# Altium schematic sheet A4988_motor_drivers.SchDoc
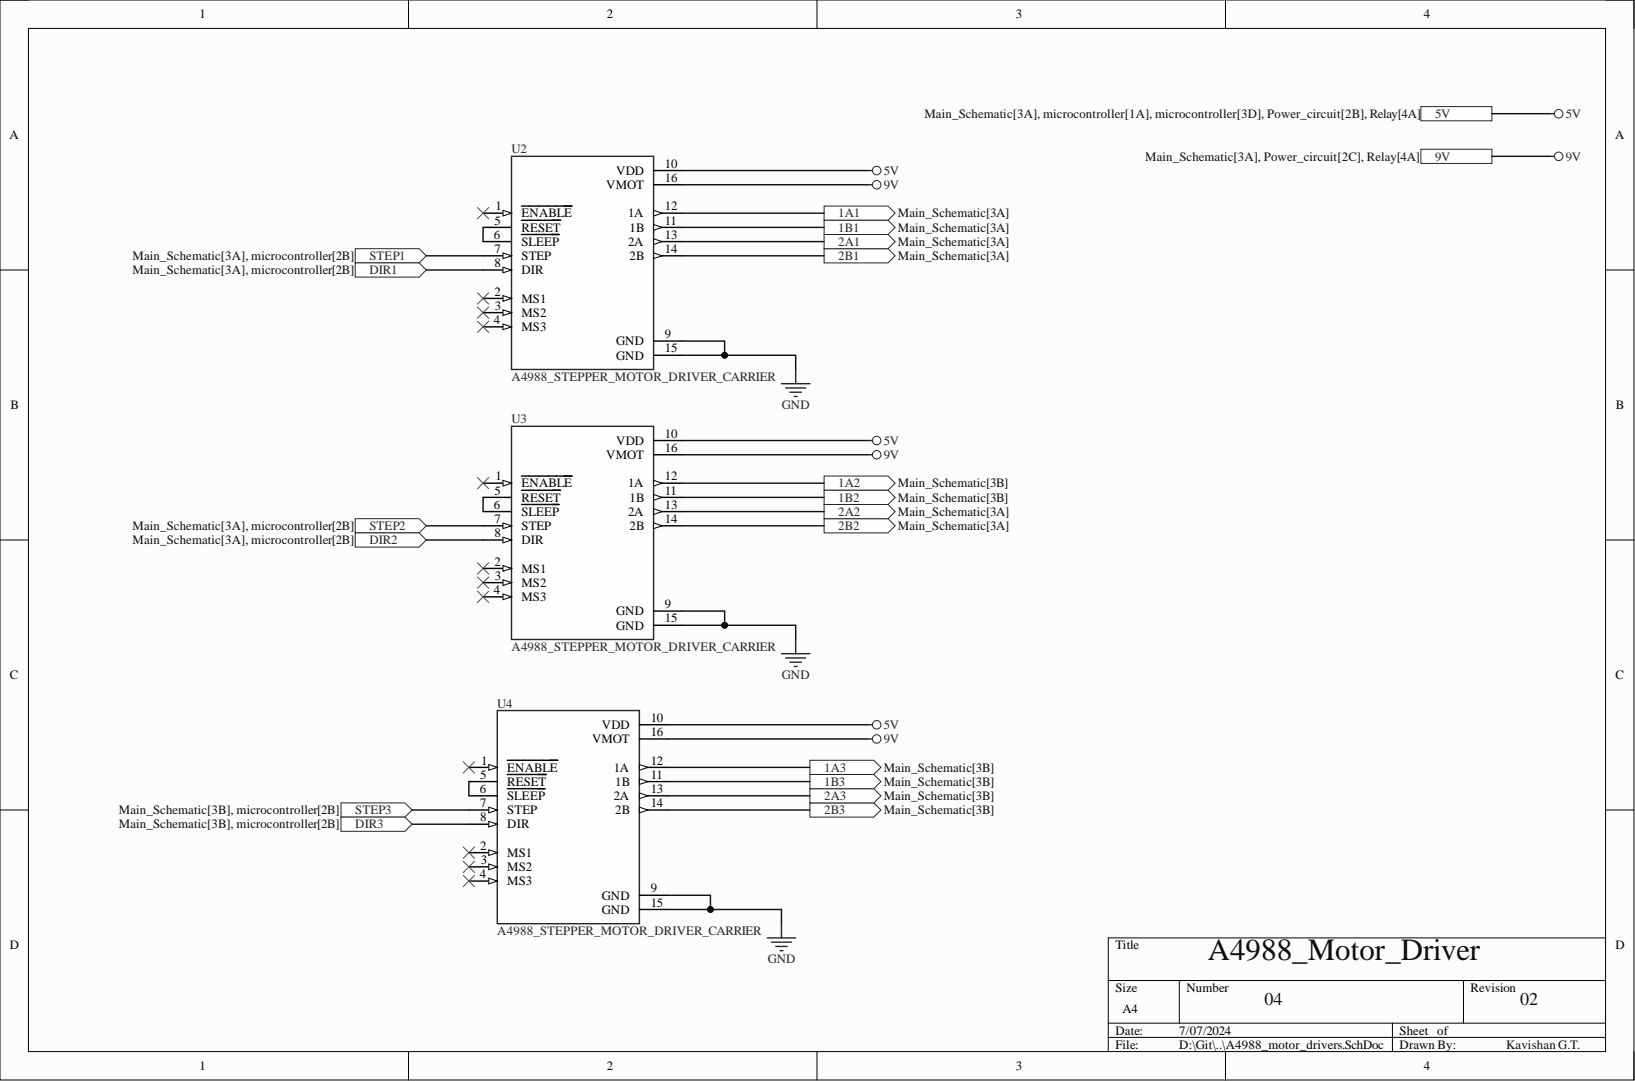
[diagram p0: A4988_motor_drivers.SchDoc]

## Parts
U2  A4988_STEPPER_MOTOR_DRIVER_CARRIER  [MODULE_A4988_STEPPER_MOTOR_DRIVER_CARRIER]
U3  A4988_STEPPER_MOTOR_DRIVER_CARRIER  [MODULE_A4988_STEPPER_MOTOR_DRIVER_CARRIER]
U4  A4988_STEPPER_MOTOR_DRIVER_CARRIER  [MODULE_A4988_STEPPER_MOTOR_DRIVER_CARRIER]

## Ports
1A1, 1A2, 1A3, 1B1, 1B2, 1B3, 2A1, 2A2, 2A3, 2B1, 2B2, 2B3, 5V, 9V, DIR1, DIR2, DIR3, STEP1, STEP2, STEP3

## Nets
GND: U2.9(GND) U2.15(GND) U3.9(GND) U3.15(GND) U4.9(GND) U4.15(GND)
1A1: U2.12(1A) ; port 1A1
1A2: U3.12(1A) ; port 1A2
1A3: U4.12(1A) ; port 1A3
1B1: U2.11(1B) ; port 1B1
1B2: U3.11(1B) ; port 1B2
1B3: U4.11(1B) ; port 1B3
2A1: U2.13(2A) ; port 2A1
2A2: U3.13(2A) ; port 2A2
2A3: U4.13(2A) ; port 2A3
2B1: U2.14(2B) ; port 2B1
2B2: U3.14(2B) ; port 2B2
2B3: U4.14(2B) ; port 2B3
5V: U2.10(VDD) U3.10(VDD) U4.10(VDD) ; port 5V
9V: U2.16(VMOT) U3.16(VMOT) U4.16(VMOT) ; port 9V
DIR1: U2.8(DIR) ; port DIR1
DIR2: U3.8(DIR) ; port DIR2
DIR3: U4.8(DIR) ; port DIR3
STEP1: U2.7(STEP) ; port STEP1
STEP2: U3.7(STEP) ; port STEP2
STEP3: U4.7(STEP) ; port STEP3
NetU2_5: U2.5(RESET) U2.6(SLEEP)
NetU3_5: U3.5(RESET) U3.6(SLEEP)
NetU4_5: U4.5(RESET) U4.6(SLEEP)

Not connected: U2 1(ENABLE) 2(MS1) 3(MS2) 4(MS3); U3 1(ENABLE) 2(MS1) 3(MS2) 4(MS3); U4 1(ENABLE) 2(MS1) 3(MS2) 4(MS3)

## Library data of the parts
U2-U4 = A4988_STEPPER_MOTOR_DRIVER_CARRIER: Stepper motor controler; IC: A4988; 1A; Uin mot: 8Ã·35V; Availability: Unavailable; Component Kind: Standard; Library Name: A4988_STEPPER_MOTOR_DRIVER_CARRIER.IntLib; MP: A4988_STEPPER_MOTOR_DRIVER_CARRIER; Package: None; Price: None
